# Altium schematic sheet [09] - USB-JTAG, USB-UART.SchDoc("[09] - USB-JTAG, USB-UART")
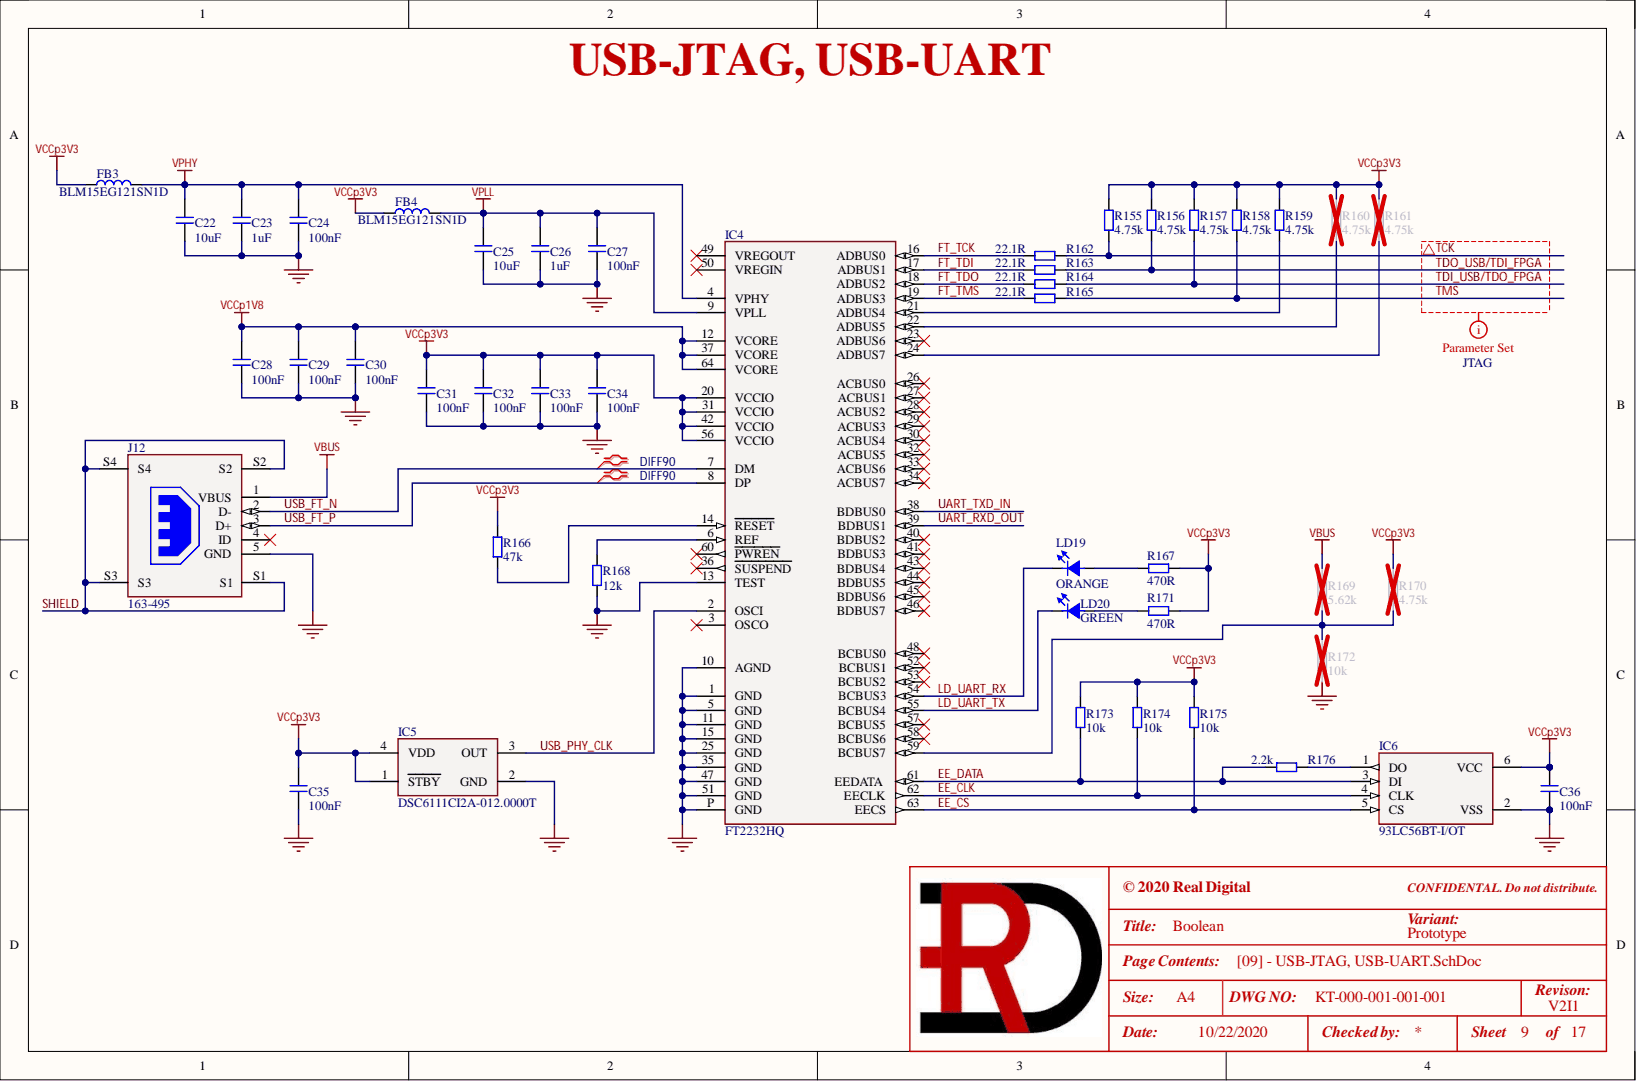
[diagram p0: [09] - USB-JTAG, USB-UART.SchDoc]

## Parts
C22  10uF  [C0805]
C23  1uF  [C0603]
C24  100nF  [C0402]
C25  10uF  [C0805]
C26  1uF  [C0603]
C27  100nF  [C0402]
C28  100nF  [C0402]
C29  100nF  [C0402]
C30  100nF  [C0402]
C31  100nF  [C0402]
C32  100nF  [C0402]
C33  100nF  [C0402]
C34  100nF  [C0402]
C35  100nF  [C0402]
C36  100nF  [C0402]
FB3  BLM15EG121SN1D  [BLM15]
FB4  BLM15EG121SN1D  [BLM15]
IC4  FT2232HQ  [QFN64-FTDI]
IC5  DSC6111CI2A-012.0000T  [DSC3.2x2.5-4]
IC6  93LC56BT-I/OT  [SOT23-6_Microchip]
J12  163-495  [163-495]
LD19  ORANGE  [LD0603_ORANGE]
LD20  GREEN  [LD0603_Green]
R155  4.75k  [R0402]
R156  4.75k  [R0402]
R157  4.75k  [R0402]
R158  4.75k  [R0402]
R159  4.75k  [R0402]
R160  4.75k  [R0402]
R161  4.75k  [R0402]
R162  22.1R  [R0402]
R163  22.1R  [R0402]
R164  22.1R  [R0402]
R165  22.1R  [R0402]
R166  47k  [R0402]
R167  470R  [R0402]
R168  12k  [R0402]
R169  5.62k  [R0402]
R170  4.75k  [R0402]
R171  470R  [R0402]
R172  10k  [R0402]
R173  10k  [R0402]
R174  10k  [R0402]
R175  10k  [R0402]
R176  2.2k  [R0402]

## Nets
GND: C22.1 C23.1 C24.1 C25.1 C26.1 C27.1 C28.1 C29.1 C30.1 C31.1 C32.1 C33.1 C34.1 C35.1 C36.1 IC4.1(GND) IC4.5(GND) IC4.10(AGND) IC4.11(GND) IC4.13(TEST) IC4.15(GND) IC4.25(GND) IC4.35(GND) IC4.47(GND) IC4.51(GND) IC4.P(GND) IC5.2(GND) IC6.2(VSS) J12.5(GND) R168.1 R172.1
VBUS: J12.1(VBUS) R169.2
VCCp1V8: C28.2 C29.2 C30.2 IC4.12(VCORE) IC4.37(VCORE) IC4.64(VCORE)
VCCp3V3: C31.2 C32.2 C33.2 C34.2 C35.2 C36.2 FB3.1 FB4.1 IC4.20(VCCIO) IC4.31(VCCIO) IC4.42(VCCIO) IC4.56(VCCIO) IC5.1(STBY) IC5.4(VDD) IC6.6(VCC) R155.2 R156.2 R157.2 R158.2 R159.2 R160.2 R161.2 R166.2 R167.2 R170.2 R171.2 R173.2 R174.2 R175.2
VPHY: C22.2 C23.2 C24.2 FB3.2 IC4.4(VPHY)
VPLL: C25.2 C26.2 C27.2 FB4.2 IC4.9(VPLL)
EE_CLK: IC4.62(EECLK) IC6.4(CLK) R174.1
EE_CS: IC4.63(EECS) IC6.5(CS) R175.1
EE_DATA: IC4.61(EEDATA) IC6.3(DI) R173.1 R176.1
FT_TCK: IC4.16(ADBUS0) R162.1
FT_TDI: IC4.17(ADBUS1) R163.1
FT_TDO: IC4.18(ADBUS2) R164.1
FT_TMS: IC4.19(ADBUS3) R165.1
LD_UART_RX: IC4.54(BCBUS3) LD19.K
LD_UART_TX: IC4.55(BCBUS4) LD20.K
SHIELD: J12.S1 J12.S2 J12.S3 J12.S4
TCK: R155.1 R162.2
TDI_USB/TDO_FPGA: R157.1 R164.2
TDO_USB/TDI_FPGA: R156.1 R163.2
TMS: R158.1 R165.2
UART_RXD_OUT: IC4.39(BDBUS1)
UART_TXD_IN: IC4.38(BDBUS0)
USB_FT_N: IC4.7(DM) J12.2(D-)
USB_FT_P: IC4.8(DP) J12.3(D+)
USB_PHY_CLK: IC4.2(OSCI) IC5.3(OUT)
NetIC4_6: IC4.6(REF) R168.2
NetIC4_14: IC4.14(RESET) R166.1
NetIC4_21: IC4.21(ADBUS4) R159.1
NetIC4_22: IC4.22(ADBUS5) R160.1
NetIC4_24: IC4.24(ADBUS7) R161.1
NetIC4_59: IC4.59(BCBUS7) R169.1 R170.1 R172.2
NetIC6_1: IC6.1(DO) R176.2
NetLD19_A: LD19.A R167.1
NetLD20_A: LD20.A R171.1

Not connected: IC4 3(OSCO) 23(ADBUS6) 26(ACBUS0) 27(ACBUS1) 28(ACBUS2) 29(ACBUS3) 30(ACBUS4) 32(ACBUS5) 33(ACBUS6) 34(ACBUS7) 36(SUSPEND) 40(BDBUS2) 41(BDBUS3) 43(BDBUS4) 44(BDBUS5) 45(BDBUS6) 46(BDBUS7) 48(BCBUS0) 49(VREGOUT) 50(VREGIN) 52(BCBUS1) 53(BCBUS2) 57(BCBUS5) 58(BCBUS6) 60(PWREN); J12 4(ID)

## Library data of the parts
C22 C25 = GRM21BR71A106KA73L: CAP CER 10uF 10V X7R 0805; Manufacturer 1: Murata Electronics; .Checked_PCB: AC; .Creator: RV; C P/N: 111-0789; LoadStatus: Load; Tolerance: 10%; Category: Capacitors; Component Kind: Standard; Library Name: KT-RealDigital.IntLib; Manufacturer 1 Part Number: GRM21BR71A106KA73L; Temperature Coefficient: X7R; Voltage - Rated: 10V; .Checked_SCH: AC
C23 C26 = GCJ188R71E105KA01D: CAP CER 1uF 25V X7R 0603; Manufacturer 1: Murata Electronics; .Checked_PCB: AC; .Creator: RV; C P/N: 111-0602; LoadStatus: Load; Tolerance: 10%; Category: Capacitors; Component Kind: Standard; Library Name: KT-RealDigital.IntLib; Manufacturer 1 Part Number: GCJ188R71E105KA01D; Temperature Coefficient: X7R; Voltage - Rated: 25V; .Checked_SCH: AC
C24 C27-C36 = GRM155R71E104KE14J: CAP CER 0.1uF 25V X7R 0402; Manufacturer 1: Murata Electronics; .Checked_PCB: AC; .Creator: RV; C P/N: RD-111-0006; LoadStatus: Load; Tolerance: 10%; Category: Capacitors; Component Kind: Standard; Library Name: KT-RealDigital.IntLib; Manufacturer 1 Part Number: GRM155R71E104KE14J; Temperature Coefficient: X7R; Voltage - Rated: 25V; .Checked_SCH: AC
FB3 FB4 = BLM15EG121SN1D: FERRITE BEAD 120 OHM 0402 1LN; Manufacturer 1: Murata Electronics; .Checked_PCB: AC; .Creator: RV; C P/N: 131-0493; LoadStatus: Load; Category: Ferrite Beads; Component Kind: Standard; Library Name: KT-RealDigital.IntLib; Manufacturer 1 Part Number: BLM15EG121SN1D; .Checked_SCH: AC
IC4 = FT2232HQ: IC USB HS DUAL UART/FIFO 64-QFN; Manufacturer 1: FTDI; Manufacturer 1 Part Number: FT2232HQ-REEL; .Checked_PCB: AC; .Creator: RV; C P/N: 126-0695; LoadStatus: Load; Category: IC; Component Kind: Standard; Library Name: KT-RealDigital.IntLib; .Checked_SCH: AC
IC5 = DSC6111CI2A-012.0000T: 12MHz XO (Standard) CMOS Oscillator 1.8V ~ 3.3V Standby (Power Down) 4-SMD, No Lead; Manufacturer 1: Microchip; Manufacturer 2 Part Number: SMA-012000-3CY4T1; .Checked_PCB: AC; .Creator: RV; C P/N: 126-1425; LoadStatus: Load; Category: Oscillators; Component Kind: Standard; Library Name: KT-RealDigital.IntLib; Manufacturer 1 Part Number: DSC6111CI2A-012.0000T; Manufacturer 2: AKER TECHNOLOGY CO., LTD.; .Checked_SCH: AC
IC6 = 93LC56BT-I/OT: 2K Microwire Compatible Serial EEPROM; Manufacturer 1: Microchip; .Checked_PCB: AC; .Creator: RV; C P/N: 126-0703; LoadStatus: Load; Category: IC; Component Kind: Standard; Library Name: KT-RealDigital.IntLib; Manufacturer 1 Part Number: 93LC56BT-I/OT; .Checked_SCH: AC
J12 = 163-495: CONN RCPT USB2.0 MICRO B SMD R/A; .Creator: RV; C P/N: 163-0495; LoadStatus: Load; Category: Connectors; Component Kind: Standard; Library Name: KT-RealDigital.IntLib; Manufacturer 1 Part Number: 163-495
LD19 = LED_ORANGE: LED ORANGE 0603; Manufacturer 1: Victory Electronics; Manufacturer 1 Part Number: VS 28A8M; .Checked_PCB: AC; .Creator: RV; C P/N: 121-1275; LoadStatus: Load; Category: Led; Component Kind: Standard; Library Name: KT-RealDigital.IntLib; .Checked_SCH: AC
LD20 = LED_GREEN: LED GREEN 0603; Manufacturer 1: Everlight Electronics CO., LTD; Manufacturer 1 Part Number: 19-21SYGC/S530-E4/TR8; .Checked_PCB: AC; .Creator: RV; C P/N: 121-0006; LoadStatus: Load; Category: Led; Component Kind: Standard; Library Name: KT-RealDigital.IntLib; .Checked_SCH: AC
R155-R161 R170 = RC0402FR-074K75P: RES SMD 4.75K OHM 1% 1/16W 0402; Manufacturer 1: Yageo; .Checked_PCB: AC; .Creator: RV; C P/N: 101-0124; LoadStatus: Load; Category: Resistors; Component Kind: Standard; Library Name: KT-RealDigital.IntLib; Manufacturer 1 Part Number: RC0402FR-074K75P; Tolerance: 1%; .Checked_SCH: AC
R162-R165 = RC0402FR-0722R1L: RES SMD 22.1 OHM 1% 1/16W 0402; Manufacturer 1: Yageo; .Checked_PCB: AC; .Creator: RV; C P/N: RD-101-0002; LoadStatus: Load; Category: Resistors; Component Kind: Standard; Library Name: KT-RealDigital.IntLib; Manufacturer 1 Part Number: RC0402FR-0722R1L; Tolerance: 1%; .Checked_SCH: AC
R166 = RC0402JR-0747KL: RES SMD 47K OHM 5% 1/16W 0402; Manufacturer 1: Yageo; .Checked_PCB: AC; .Creator: RV; C P/N: 101-0074; LoadStatus: Load; Category: Resistors; Component Kind: Standard; Library Name: KT-RealDigital.IntLib; Manufacturer 1 Part Number: RC0402JR-0747KL; Tolerance: 5%; .Checked_SCH: AC
R167 R171 = RC0402JR-07470RL: RES SMD 470 OHM 5% 1/16W 0402; Manufacturer 1: Yageo; .Checked_PCB: AC; .Creator: RV; C P/N: 101-0052; LoadStatus: Load; Category: Resistors; Component Kind: Standard; Library Name: KT-RealDigital.IntLib; Manufacturer 1 Part Number: RC0402JR-07470RL; Tolerance: 5%; .Checked_SCH: AC
R168 = RC0402FR-0712KL: RES SMD 12K OHM 1% 1/16W 0402; Manufacturer 1: Yageo; .Checked_PCB: AC; .Creator: RV; C P/N: 101-0643; LoadStatus: Load; Category: Resistors; Component Kind: Standard; Library Name: KT-RealDigital.IntLib; Manufacturer 1 Part Number: RC0402FR-0712KL; Tolerance: 1%; .Checked_SCH: AC
R169 = RC0402FR-075K62L: RES SMD 5.62K OHM 1% 1/16W 0402; Manufacturer 1: Yageo; .Checked_PCB: AC; .Creator: RV; LoadStatus: Load; Category: Resistors; Component Kind: Standard; Library Name: KT-RealDigital.IntLib; Manufacturer 1 Part Number: RC0402FR-075K62L; Tolerance: 1%; .Checked_SCH: AC
R172-R175 = RC0402JR-0710KL: RES SMD 10K OHM 5% 1/16W 0402; Manufacturer 1: Yageo; .Checked_PCB: AC; .Creator: RV; C P/N: 101-0047; LoadStatus: Load; Category: Resistors; Component Kind: Standard; Library Name: KT-RealDigital.IntLib; Manufacturer 1 Part Number: RC0402JR-0710KL; Tolerance: 5%; .Checked_SCH: AC
R176 = RC0402JR-072K2L: RES SMD 2.2K OHM 5% 1/16W 0402; Manufacturer 1: Yageo; .Checked_PCB: AC; .Creator: RV; C P/N: RD-101-0005; LoadStatus: Load; Category: Resistors; Component Kind: Standard; Library Name: KT-RealDigital.IntLib; Manufacturer 1 Part Number: RC0402JR-072K2L; Tolerance: 5%; .Checked_SCH: AC
